# Altium schematic sheet Sheet6.SchDoc(Sheet6)
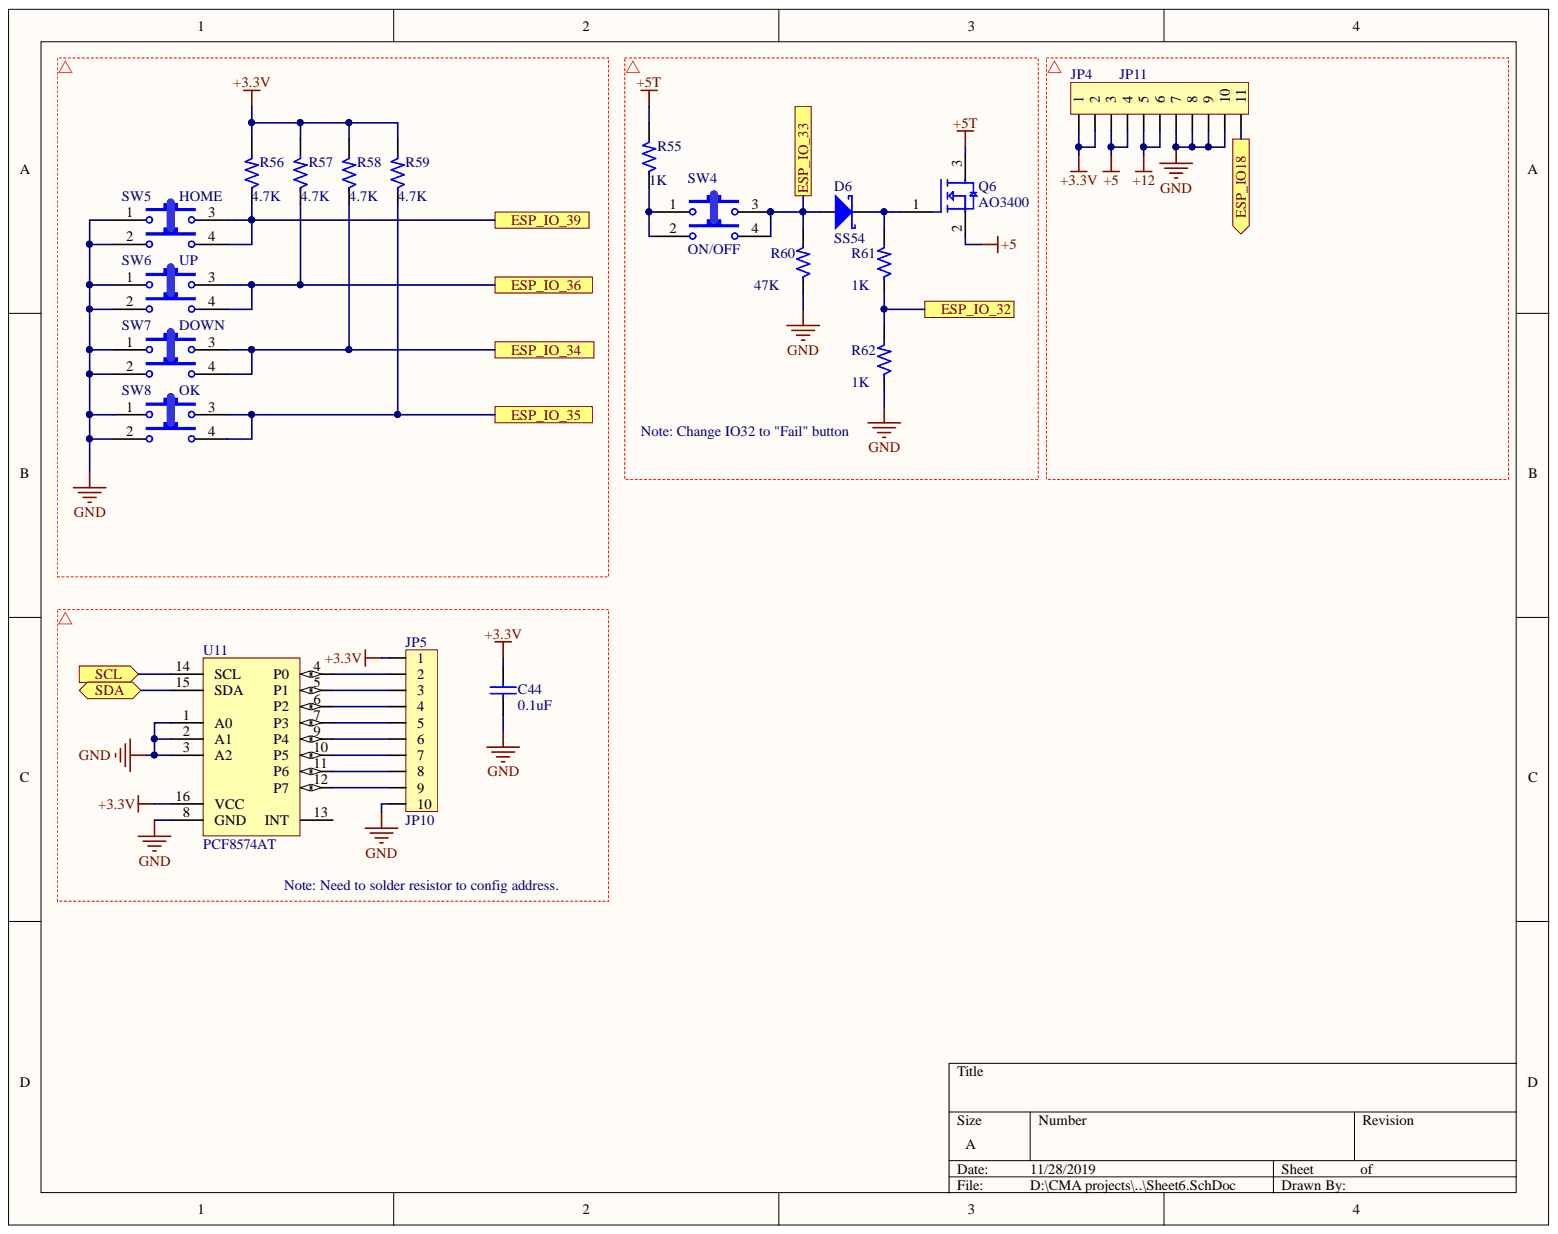
[diagram p0: Sheet6.SchDoc]

## Parts
C44  value 0.1uF  [0603]  (hidden comment: Capacitor Ceramic)
D6  SS54  [SMA]
JP4  JP11  [CON11]
JP5  JP10  [CON10]
Q6  AO3400  [SOT23A - duplicate]
R55  1K  [RES-SMD-0603]
R56  4.7K  [RES-SMD-0603]
R57  4.7K  [RES-SMD-0603]
R58  4.7K  [RES-SMD-0603]
R59  4.7K  [RES-SMD-0603]
R60  value 47K  [RES-SMD-0603]  (hidden comment: 1K)
R61  1K  [RES-SMD-0603]
R62  1K  [RES-SMD-0603]
SW4  ON/OFF  [SW-12mm]
SW5  HOME  [SW-12mm]
SW6  UP  [SW-12mm]
SW7  DOWN  [SW-12mm]
SW8  OK  [SW-12mm]
U11  PCF8574AT  [SO-16 - duplicate1]

## Ports
ESP_IO18, ESP_IO_32, ESP_IO_33, ESP_IO_34, ESP_IO_35, ESP_IO_36, ESP_IO_39, SCL, SDA

## Nets
+3.3V: C44.1 JP4.1 JP4.2 JP5.1 R56.2 R57.2 R58.2 R59.2 U11.16(VCC)
+5: JP4.3 JP4.4 Q6.2
+5T: Q6.3 R55.2
+12: JP4.5 JP4.6
GND: C44.2 JP4.7 JP4.8 JP4.9 JP4.10 JP5.10 R60.1 R62.1 SW5.1 SW5.2 SW6.1 SW6.2 SW7.1 SW7.2 SW8.1 SW8.2 U11.1(A0) U11.2(A1) U11.3(A2) U11.8(GND)
ESP_IO18: JP4.11 ; port ESP_IO18
ESP_IO_32: R61.1 R62.2 ; port ESP_IO_32
ESP_IO_33: D6.1 R60.2 SW4.3 SW4.4 ; port ESP_IO_33
ESP_IO_34: R58.1 SW7.3 SW7.4 ; port ESP_IO_34
ESP_IO_35: R59.1 SW8.3 SW8.4 ; port ESP_IO_35
ESP_IO_36: R57.1 SW6.3 SW6.4 ; port ESP_IO_36
ESP_IO_39: R56.1 SW5.3 SW5.4 ; port ESP_IO_39
SCL: U11.14(SCL) ; port SCL
SDA: U11.15(SDA) ; port SDA
NetD6_2: D6.2 Q6.1 R61.2
NetJP5_2: JP5.2 U11.4(P0)
NetJP5_3: JP5.3 U11.5(P1)
NetJP5_4: JP5.4 U11.6(P2)
NetJP5_5: JP5.5 U11.7(P3)
NetJP5_6: JP5.6 U11.9(P4)
NetJP5_7: JP5.7 U11.10(P5)
NetJP5_8: JP5.8 U11.11(P6)
NetJP5_9: JP5.9 U11.12(P7)
NetR55_1: R55.1 SW4.1 SW4.2

Not connected: U11 13(INT)

## Library data of the parts
C44 = CapSMD: Capacitor; Simulation: CAP; Signal Integrity: Cap; Published: 8-Jun-2000; PackageReference: RAD-0.3; Library Name: Cty1.SchLib; LatestRevisionNote: Re-released for DXP Platform.; LatestRevisionDate: 17-Jul-2002; Component Kind: Standard; Publisher: Altium Limited
D6 = DIODE SCHOTTKY: Schottky Diode; Library Name: Cty1.SchLib; Component Kind: Standard
JP4 = Con11: Connector 11; Value: Connector 11; Library Name: Cty1.SchLib; Component Kind: Standard
JP5 = Con10: Connector 10; Value: Connector 10; Library Name: Cty1.SchLib; Component Kind: Standard
Q6 = MOSFET N: SOT23-3 N-1CH 5A 30V; Library Name: Cty1.SchLib; Component Kind: Standard
R55-R62 = Res: Resistor 1/4W; Library Name: Cty1.SchLib; Component Kind: Standard
  R55 R61 R62: Value: 1K
  R56-R59: Value: 4.7K
SW4-SW8 = SW-12mm: Library Name: Cty1.SchLib; Component Kind: Standard
U11 = PCF8574AT: I/O Expanders EXTENDED I/O EXPANDER I2C; Library Name: Cty1.SchLib; Component Kind: Standard
